# Altium schematic sheet GP_LDO_Circuit_Sheet.SchDoc(GP_LDO_Circuit_Sheet)
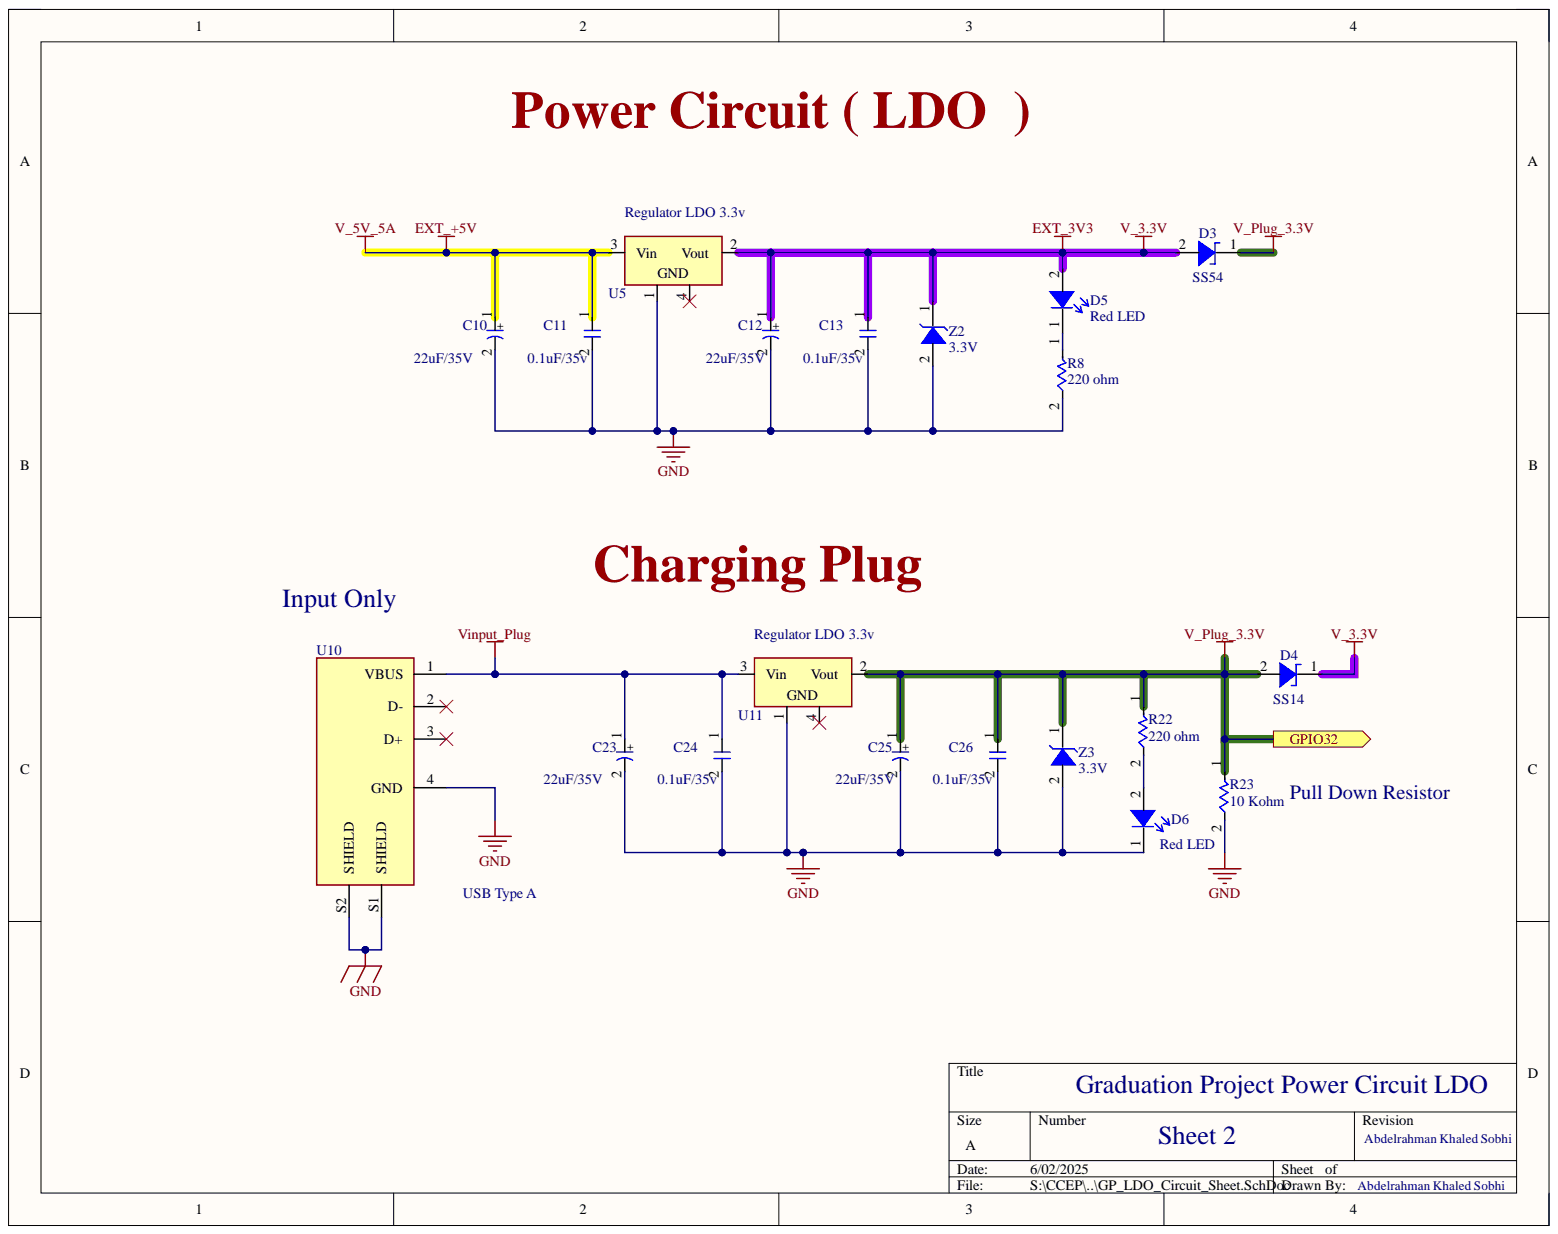
[diagram p0: GP_LDO_Circuit_Sheet.SchDoc]

## Parts
C10  22uF/35V  [Electrolytic Capacitor 22UF]
C11  0.1uF/35v  [Capacitor 100nF]
C12  22uF/35V  [Electrolytic Capacitor 22UF]
C13  0.1uF/35v  [Capacitor 100nF]
C23  22uF/35V  [Electrolytic Capacitor 22UF]
C24  0.1uF/35v  [Capacitor 100nF]
C25  22uF/35V  [Electrolytic Capacitor 22UF]
C26  0.1uF/35v  [Capacitor 100nF]
D3  SS54  [Schottky SS54]
D4  SS14  [Schottky SS14]
D5  Red LED  [Red Led]
D6  Red LED  [Red Led]
R8  220 ohm  [Resistor 1Kohm]
R22  220 ohm  [Resistor 1Kohm]
R23  10 Kohm  [Resistor 1Kohm]
U5  Regulator LDO 3.3v  [LDO 3.3V]
U10  USB Type A  [USB Type A]
U11  Regulator LDO 3.3v  [LDO 3.3V]
Z2  3.3V  [Zener 3.3V Diode]
Z3  3.3V  [Zener 3.3V Diode]

## Ports
GPIO32

## Nets
EXT_3V3: C12.1 C13.1 D3.2 D4.1 D5.2 U5.2(Vout) Z2.1
EXT_+5V: C10.1 C11.1 U5.3(Vin)
GND: C10.2 C11.2 C12.2 C13.2 C23.2 C24.2 C25.2 C26.2 D6.1 R8.2 R23.2 U5.1 U10.4(GND) U10.S1(SHIELD) U10.S2(SHIELD) U11.1 Z2.2 Z3.2
Vinput_Plug: C23.1 C24.1 U10.1(VBUS) U11.3(Vin)
V_Plug_3.3V: C25.1 C26.1 D3.1 D4.2 R22.1 R23.1 U11.2(Vout) Z3.1 ; port GPIO32
NetD5_1: D5.1 R8.1
NetD6_2: D6.2 R22.2

Not connected: U5 4; U10 2(D-) 3(D+); U11 4

## Library data of the parts
C10 C12 C23 C25 = Electrolytic Capacitor 22UF: Electrolytic Capacitor (22UF , 35V)SMD Radial Electrolytic Capacitor; Component Kind: Standard; ComponentLink1Description: Market Link; ComponentLink1URL: https://free-electronic.com/product/smd-electrolytic-capacitor-22uf-35v/; Library Name: GP_Integrated_Library.IntLib
C11 C13 C24 C26 = Capacitor 100nF: Ceramic Capacitor (100nF , 50V) SMD (1206); Component Kind: Standard; ComponentLink1Description: Link; ComponentLink1URL: https://free-electronic.com/product/ceramic-capacitor-100nf-50v-smd-1206/; Library Name: GP_Integrated_Library.IntLib
D3 = Schottky SS54: SMD Schottky diode 5A 40V 1N5824 SS54 DO-214AB SMC; Component Kind: Standard; ComponentLink1Description: Part Number; ComponentLink1URL: 1N5824 SS54; ComponentLink2Description: Market Link; ComponentLink2URL: https://uge-one.com/product/smd-schottky-diode-5a-40v-1n5824-ss54-do-214ab-smc/; Library Name: GP_Integrated_Library.IntLib
D4 = Schottky SS14: SMD Schottky diode 5A 40V 1N5824 SS54 DO-214AB SMC; Component Kind: Standard; ComponentLink1Description: Part Number; ComponentLink1URL: 1N5824 SS54; ComponentLink2Description: Market Link; ComponentLink2URL: https://uge-one.com/product/smd-schottky-diode-5a-40v-1n5824-ss54-do-214ab-smc/; Library Name: GP_Integrated_Library.IntLib
D5 D6 = Red LED: 2012 Smd Led Red; Component Kind: Standard; ComponentLink1Description: Part Number; ComponentLink1URL: SM0603UYC; ComponentLink2Description: Market Link; ComponentLink2URL: https://free-electronic.com/product/2012-smd-led-red/; Library Name: GP_Integrated_Library.IntLib
R8 R22 R23 = Resistor 1 Kohm: SMD RESISTORS 200V-500V 1206; Component Kind: Standard; ComponentLink1Description: Part Number; ComponentLink1URL: A1-20-1206-4.7K; ComponentLink2Description: Market Link; ComponentLink2URL: https://free-electronic.com/product/smd-resistor-1-kohm-1-4w/; Library Name: GP_Integrated_Library.IntLib
U5 U11 = Regulator LDO 3.3v: (+3.3V , 800mA ) Low-Dropout Voltage Regulator.(TO-220); Component Kind: Standard; ComponentLink1Description: Part Number; ComponentLink1URL: LM1117T-3.3; ComponentLink2Description: Market Link; ComponentLink2URL: https://free-electronic.com/product/ams1117-3-3-smd/; ComponentLink3Description: New link; Library Name: GP_Integrated_Library.IntLib
Z2 Z3 = Zener 3.3V: Zener Diodes ( 3.3V ) SMD SOD-123; Component Kind: Standard; ComponentLink1Description: Market Link; ComponentLink1URL: https://free-electronic.com/product/mm1z3v3-w3-smd/; Library Name: GP_Integrated_Library.IntLib
U10 = USB Type A: Component Kind: Standard; Library Name: GP_Integrated_Library.IntLib
